# Altium schematic sheet Shunt.SchDoc
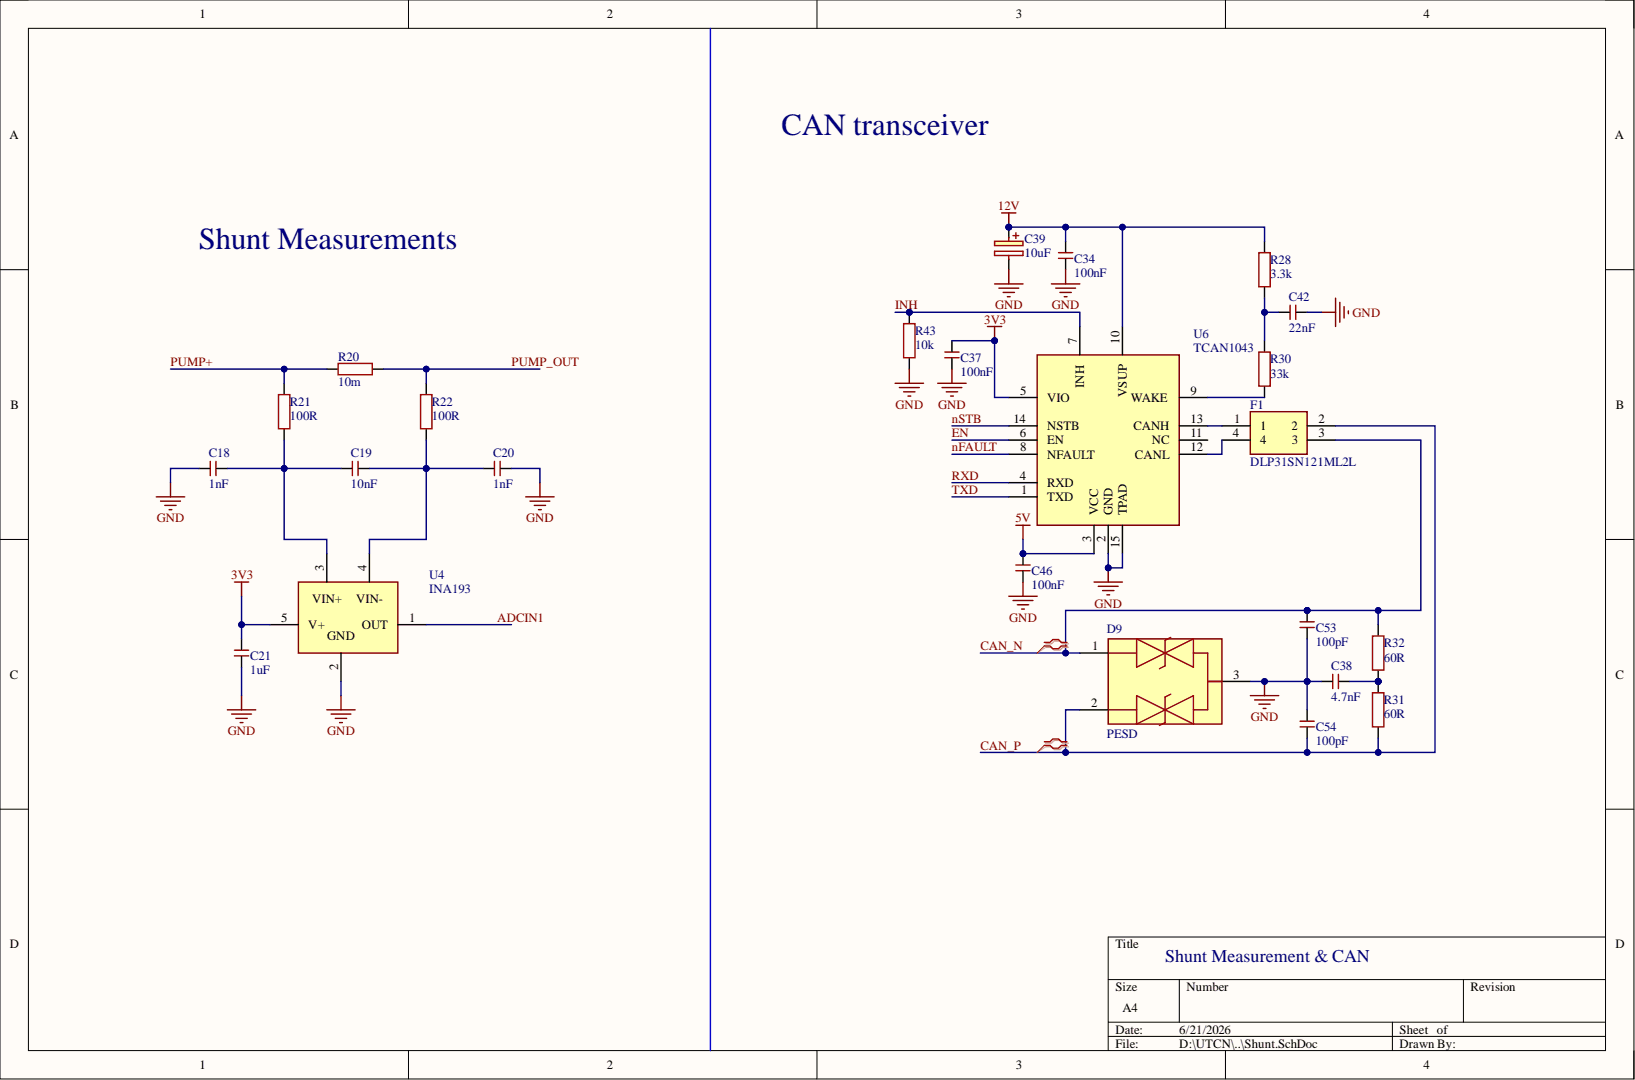
[diagram p0: Shunt.SchDoc]

## Parts
C18  1nF  [C0402]
C19  10nF  [C0402]
C20  1nF  [C0402]
C21  1uF  [C0402]
C34  100nF  [C0402]
C37  100nF  [C0402]
C38  4.7nF  [C0402]
C39  10uF  [CAPAE660X565N]
C42  22nF  [C0402]
C46  100nF  [C0402]
C53  100pF  [C0402]
C54  100pF  [C0402]
D9  PESD  [PESD2CANFD36LTQR]
F1  DLP31SN121ML2L  [DLP31SN121ML2B]
R20  10m  [CSS0402FT10L0]
R21  100R  [R0402]
R22  100R  [R0402]
R28  3.3k  [R0402]
R30  33k  [R0402]
R31  60R  [R0201]
R32  60R  [R0201]
R43  10k  [R0402]
U4  INA193  [SOT95P280X145-5N]
U6  TCAN1043  [SON65P300X450X90-15N]

## Nets
3V3: C21.2 C37.2 U4.5(V+) U6.5(VIO)
5V: C46.2 U6.3(VCC)
12V: C34.2 C39.1 R28.2 U6.10(VSUP)
GND: C18.1 C20.2 C21.1 C34.1 C37.1 C38.2 C39.2 C42.1 C46.1 C53.2 C54.1 D9.3 R43.1 U4.2 U6.2(GND) U6.15(TPAD)
ADCIN1: U4.1(OUT)
CAN_N: C53.1 D9.1 F1.3 R32.1
CAN_P: C54.2 D9.2 F1.2 R31.2
EN: U6.6(EN)
INH: R43.2 U6.7(INH)
nFAULT: U6.8(NFAULT)
nSTB: U6.14(NSTB)
PUMP+: R20.1 R21.2
PUMP_OUT: R20.2 R22.2
RXD: U6.4(RXD)
TXD: U6.1(TXD)
NetC18_2: C18.2 C19.1 R21.1 U4.3
NetC19_2: C19.2 C20.1 R22.1 U4.4
NetC38_1: C38.1 R31.1 R32.2
NetC42_2: C42.2 R28.1 R30.2
NetF1_1: F1.1 U6.13(CANH)
NetF1_4: F1.4 U6.12(CANL)
NetR30_1: R30.1 U6.9(WAKE)

Not connected: U6 11(NC)

## Library data of the parts
C18 C20 = C0402C102J5GACAUTO: Ceramic Capacitor, Multilayer, Ceramic, 50V, 5% +Tol, 5% -Tol, C0G, 30ppm/Cel TC, 0.001uF, Surface Mount, 0402; Manufacturer: KEMET; Multilayer: Yes; Reference Standard: AEC-Q200; Capacitor Type: Ceramic; Case/Package: 0402; Category: Ceramic Capacitors; Component Kind: Standard; ComponentLink1Description: https://datasheet.ciiva.com:443/pdfs/VipMasterIC/IC/KEME/KEME-S-A0008958445/KEME-S-A0008958445-1.pdf?src-supplier=IHS+Markit; ComponentLink1URL: https://datasheet.ciiva.com:443/pdfs/VipMasterIC/IC/KEME/KEME-S-A0008958445/KEME-S-A0008958445-1.pdf?src-supplier=IHS+Markit; ComponentLink2Description: http://www.farnell.com/datasheets/2750482.pdf?src-supplier=Farnell; ComponentLink2URL: http://www.farnell.com/datasheets/2750482.pdf?src-supplier=Farnell; ComponentLink3Description: https://datasheet.octopart.com/C0402C102J5GACAUTO-KEMET-datasheet-169773461.pdf?src-supplier=Newark; ComponentLink3URL: https://datasheet.octopart.com/C0402C102J5GACAUTO-KEMET-datasheet-169773461.pdf?src-supplier=Newark; ComponentLink4Description: http://www.farnell.com/datasheets/2750482.pdf?src-supplier=Newark; ComponentLink4URL: http://www.farnell.com/datasheets/2750482.pdf?src-supplier=Newark; ComponentLink5Description: https://api.kemet.com/component-edge/download/datasheet/C0402C102J5GACAUTO.pdf?src-supplier=Digikey; ComponentLink5URL: https://api.kemet.com/component-edge/download/datasheet/C0402C102J5GACAUTO.pdf?src-supplier=Digikey; ComponentLink6Description: http://www.kemet.com/docfinder?Partnumber=C0402C102J5GACAUTO&src-supplier=Digikey; ComponentLink6URL: http://www.kemet.com/docfinder?Partnumber=C0402C102J5GACAUTO&src-supplier=Digikey; ComponentLink7Description: https://datasheet.octopart.com/C0402C102J5GACAUTO-KEMET-datasheet-130259044.pdf?src-supplier=TME; ComponentLink7URL: https://datasheet.octopart.com/C0402C102J5GACAUTO-KEMET-datasheet-130259044.pdf?src-supplier=TME; Dielectric Material: Ceramic; Height: 0.5mm; JESD-609 Code: e3; Length: 1mm; Library Name: Schlib1.SchLib; Manufacturer Part Number: C0402C102J5GACAUTO; Max Operating Temperature: 125°C; Min Operating Temperature: -55°C; Mounting Technology: Surface Mount; Package Style: SMTMeter; Packaging: Tape and Reel; Pins: 2; Pricing: 1=0.12, 10=0.048, 50=0.048, 100=0.032, 1000=0.024, 10000=0.019 (USD); Rated DC Voltage (URdc): 50V; Reach SVHC Compliant: Yes; RoHS: Yes; RoHS Compliant: Yes; Stock: 231451; Supplier: Mouser; Supplier Part Number: 80-C0402C102J5GAUTO; Temperature Characteristics Code: C0G; Terminal Finish: Nickel; Tolerance  (fill in as +-): 5%; Tolerance (fill in as +-): 5%; Value: 1nF; Width: 0.5mm
C19 = C0402T103K4RALTU: Ceramic Capacitor, Multilayer, Ceramic, 16V, 10% +Tol, 10% -Tol, X7R, 15% TC, 0.01uF, Surface Mount, 0402; Manufacturer: KEMET; Multilayer: Yes; Capacitor Type: Ceramic; Case/Package: 0402; Category: Ceramic Capacitors; Component Kind: Standard; ComponentLink1Description: https://datasheet.ciiva.com:443/pdfs/VipMasterIC/IC/KEME/KEME-S-A0008487487/KEME-S-A0008487487-1.pdf?src-supplier=IHS+Markit; ComponentLink1URL: https://datasheet.ciiva.com:443/pdfs/VipMasterIC/IC/KEME/KEME-S-A0008487487/KEME-S-A0008487487-1.pdf?src-supplier=IHS+Markit; ComponentLink2Description: https://datasheet.ciiva.com/15379/kem-c1027-x7r-cots-smd-15379193.pdf?src-supplier=Mouser; ComponentLink2URL: https://datasheet.ciiva.com/15379/kem-c1027-x7r-cots-smd-15379193.pdf?src-supplier=Mouser; ComponentLink3Description: https://datasheet.ciiva.com/148/kem-c1027-x7r-cots-smd-148853.pdf?src-supplier=Digikey; ComponentLink3URL: https://datasheet.ciiva.com/148/kem-c1027-x7r-cots-smd-148853.pdf?src-supplier=Digikey; ComponentLink4Description: https://datasheet.ciiva.com/148/kem-c1027-x7r-cots-smd-148851.pdf?src-supplier=Digikey; ComponentLink4URL: https://datasheet.ciiva.com/148/kem-c1027-x7r-cots-smd-148851.pdf?src-supplier=Digikey; ComponentLink5Description: https://datasheet.ciiva.com/148/kem-c1027-x7r-cots-smd-148852.pdf?src-supplier=Digikey; ComponentLink5URL: https://datasheet.ciiva.com/148/kem-c1027-x7r-cots-smd-148852.pdf?src-supplier=Digikey; Dielectric Material: Ceramic; Height: 0.5mm; JESD-609 Code: e0; Length: 1mm; Library Name: Schlib1.SchLib; Manufacturer Part Number: C0402T103K4RALTU; Max Operating Temperature: 125°C; Min Operating Temperature: -55°C; Mounting Technology: Surface Mount; Package Shape: Rectangular; Package Style: SMTMeter; Packaging: Tape and Reel; Pins: 2; Pricing: 1=0.58, 10=0.42, 50=0.42, 100=0.337, 1000=0.294, 10000=0.246 (USD); Rated DC Voltage (URdc): 16V; Reach SVHC Compliant: No; RoHS: No; RoHS Compliant: No; Stock: 17779; Supplier: Mouser; Supplier Part Number: 80-C0402T103K4RALTU; Temperature Characteristics Code: X7R; Terminal Finish: Nickel; Termination: Wraparound; Tolerance  (fill in as +-): 10%; Tolerance (fill in as +-): 10%; Value: 10nF; Width: 0.5mm
C21 = C0402C105K4PAC7411: Ceramic Capacitor, Multilayer, Ceramic, 16V, 10% +Tol, 10% -Tol, X5R, 15% TC, 1uF, Surface Mount, 0402; Manufacturer: KEMET; Multilayer: Yes; Capacitor Type: Ceramic; Case/Package: 0402; Category: Ceramic Capacitors; Component Kind: Standard; ComponentLink1Description: https://datasheet.ciiva.com:443/pdfs/VipMasterIC/IC/KEME/KEME-S-A0008991665/KEME-S-A0008991665-1.pdf?src-supplier=IHS+Markit; ComponentLink1URL: https://datasheet.ciiva.com:443/pdfs/VipMasterIC/IC/KEME/KEME-S-A0008991665/KEME-S-A0008991665-1.pdf?src-supplier=IHS+Markit; ComponentLink2Description: https://api.kemet.com/component-edge/download/datasheet/C0402C105K4PAC7411.pdf?src-supplier=Digikey; ComponentLink2URL: https://api.kemet.com/component-edge/download/datasheet/C0402C105K4PAC7411.pdf?src-supplier=Digikey; ComponentLink3Description: https://datasheet.octopart.com/C0402C105K4PAC7411-KEMET-datasheet-142027389.pdf?src-supplier=Farnell; ComponentLink3URL: https://datasheet.octopart.com/C0402C105K4PAC7411-KEMET-datasheet-142027389.pdf?src-supplier=Farnell; Dielectric Material: Ceramic; Library Name: Schlib1.SchLib; Manufacturer Part Number: C0402C105K4PAC7411; Max Operating Temperature: 85°C; Min Operating Temperature: -55°C; Mounting Technology: Surface Mount; Package Shape: Rectangular; Packaging: Tape and Reel; Pins: 2; Pricing: 1=0.11, 10=0.061, 50=0.061, 100=0.037, 1000=0.024, 10000=0.019 (USD); Rated DC Voltage (URdc): 16V; Reach SVHC Compliant: Yes; RoHS: Yes; RoHS Compliant: Yes; Stock: 78488; Supplier: Mouser; Supplier Part Number: 80-C0402C105K4P7411; Temperature Characteristics Code: X5R; Termination: Wraparound; Tolerance  (fill in as +-): 10%; Tolerance (fill in as +-): 10%; Value: 1uF
C34 C37 C46 = C0402C104K3PACTU: Ceramic Capacitor, Multilayer, Ceramic, 25V, 10% +Tol, 10% -Tol, X5R, 15% TC, 0.1uF, Surface Mount, 0402; Manufacturer: KEMET; Multilayer: Yes; Capacitor Type: Ceramic; Case/Package: 0402; Category: Ceramic Capacitors; Component Kind: Standard; ComponentLink1Description: https://datasheet.ciiva.com:443/pdfs/VipMasterIC/IC/KEME/KEME-S-A0008991665/KEME-S-A0008991665-1.pdf?src-supplier=IHS+Markit; ComponentLink1URL: https://datasheet.ciiva.com:443/pdfs/VipMasterIC/IC/KEME/KEME-S-A0008991665/KEME-S-A0008991665-1.pdf?src-supplier=IHS+Markit; ComponentLink2Description: http://www.farnell.com/datasheets/2748284.pdf?src-supplier=Farnell; ComponentLink2URL: http://www.farnell.com/datasheets/2748284.pdf?src-supplier=Farnell; ComponentLink3Description: http://www.farnell.com/datasheets/2748284.pdf?src-supplier=Newark; ComponentLink3URL: http://www.farnell.com/datasheets/2748284.pdf?src-supplier=Newark; ComponentLink4Description: https://datasheet.octopart.com/C0402C104K3PACTU-KEMET-datasheet-181956028.pdf?src-supplier=Element14; ComponentLink4URL: https://datasheet.octopart.com/C0402C104K3PACTU-KEMET-datasheet-181956028.pdf?src-supplier=Element14; Dielectric Material: Ceramic; JESD-609 Code: e3; Library Name: Schlib1.SchLib; Manufacturer Part Number: C0402C104K3PACTU; Max Operating Temperature: 85°C; Min Operating Temperature: -55°C; Mounting Technology: Surface Mount; Package Shape: Rectangular; Packaging: Tape and Reel; Pins: 2; Pricing: 1=0.12, 10=0.056, 50=0.056, 100=0.036, 1000=0.026, 10000=0.017 (USD); Rated DC Voltage (URdc): 25V; Reach SVHC Compliant: Yes; RoHS: Yes; RoHS Compliant: Yes; Stock: 262570; Supplier: Mouser; Supplier Part Number: 80-C0402C104K3P; Temperature Characteristics Code: X5R; Terminal Finish: Nickel; Termination: Wraparound; Tolerance  (fill in as +-): 10%; Tolerance (fill in as +-): 10%; Value: 100nF
C38 = C0402C472J4JACAUTO: Cap Ceramic 0.0047uF 16V U2J 5% Pad SMD 0402 125C T/R; Manufacturer: KEMET; Category: Ceramic Capacitors; Component Kind: Standard; Library Name: Schlib1.SchLib; Manufacturer Part Number: C0402C472J4JACAUTO; Pricing: 1=0.48, 10=0.311, 50=0.311, 100=0.216, 1000=0.162, 10000=0.112 (USD); RoHS: No; Stock: 12001; Supplier: Mouser; Supplier Part Number: 80-C0402C472J4JAUTO
C39 = EXV106M050A9GAA: Aluminum Electrolytic Capacitor, Polarized, Aluminum (wet), 50V, 20% +Tol, 20% -Tol, 10uF, Surface Mount, 2626; Manufacturer: KEMET; Capacitor Type: Aluminium; ESR (Equivalent Series Resistance): 2.6R; Leakage Current: 5uA; Ripple Current: 98mA; Tan Delta: 0.12; Case/Package: 2626; Category: Aluminum Electrolytic Capacitors; Component Kind: Standard; ComponentLink1Description: https://datasheet.ciiva.com:443/pdfs/VipMasterIC/IC/KEME/KEME-S-A0009591402/KEME-S-A0009591402-1.pdf?src-supplier=IHS+Markit; ComponentLink1URL: https://datasheet.ciiva.com:443/pdfs/VipMasterIC/IC/KEME/KEME-S-A0009591402/KEME-S-A0009591402-1.pdf?src-supplier=IHS+Markit; ComponentLink2Description: https://datasheet.ciiva.com/22698/1500293-22698078.pdf?src-supplier=Element14; ComponentLink2URL: https://datasheet.ciiva.com/22698/1500293-22698078.pdf?src-supplier=Element14; Dielectric Material: Aluminium; Height: 5.4mm; Length: 7.8mm; Library Name: Schlib1.SchLib; Manufacturer Part Number: EXV106M050A9GAA; Max Operating Temperature: 105°C; Min Operating Temperature: -55°C; Mounting Technology: Surface Mount; Package Shape: Cylindrical; Package Style: SMTMeter; Packaging: Tape and Reel; Pins: 2; Polarity: Polarized; Pricing: 1=0.48, 10=0.255, 50=0.255, 100=0.165, 1000=0.139, 10000=0.112 (USD); Rated DC Voltage (URdc): 50V; Reach SVHC Compliant: Yes; RoHS: Yes; RoHS Compliant: Yes; Stock: 17264; Supplier: Mouser; Supplier Part Number: 80-EXV106M050A9GAA; Termination: Flat; Tolerance  (fill in as +-): 20%; Tolerance (fill in as +-): 20%; Value: 10uF; Width: 6.6mm
C42 = C0402C223J5RACTU: Ceramic Capacitor, Multilayer, Ceramic, 50V, 5% +Tol, 5% -Tol, X7R, 15% TC, 0.022uF, Surface Mount, 0402; Manufacturer: KEMET; Multilayer: Yes; Capacitor Type: Ceramic; Case/Package: 0402; Category: Ceramic Capacitors; Component Kind: Standard; ComponentLink1Description: https://datasheet.ciiva.com:443/pdfs/VipMasterIC/IC/KEME/KEME-S-A0008991654/KEME-S-A0008991654-1.pdf?src-supplier=IHS+Markit; ComponentLink1URL: https://datasheet.ciiva.com:443/pdfs/VipMasterIC/IC/KEME/KEME-S-A0008991654/KEME-S-A0008991654-1.pdf?src-supplier=IHS+Markit; ComponentLink2Description: http://capacitoredge.kemet.com/capedge2/DataSheet?pn=C0402C223J5RAC7867&src-supplier=Avnet; ComponentLink2URL: http://capacitoredge.kemet.com/capedge2/DataSheet?pn=C0402C223J5RAC7867&src-supplier=Avnet; Dielectric Material: Ceramic; Height: 0.5mm; JESD-609 Code: e3; Length: 1mm; Library Name: Schlib1.SchLib; Manufacturer Part Number: C0402C223J5RACTU; Max Operating Temperature: 125°C; Min Operating Temperature: -55°C; Mounting Technology: Surface Mount; Package Shape: Rectangular; Package Style: SMTMeter; Packaging: Tape and Reel; Pins: 2; Pricing: 1=0.3, 10=0.185, 50=0.185, 100=0.12, 1000=0.087, 10000=0.063 (USD); Rated DC Voltage (URdc): 50V; Reach SVHC Compliant: Yes; RoHS: Yes; RoHS Compliant: Yes; Stock: 18324; Supplier: Mouser; Supplier Part Number: 80-C0402C223J5RACTU; Temperature Characteristics Code: X7R; Terminal Finish: Nickel; Termination: Wraparound; Tolerance  (fill in as +-): 5%; Tolerance (fill in as +-): 5%; Value: 22nF; Width: 0.5mm
C53 C54 = C0402C101K3RACAUTO: Multilayer Ceramic Capacitors MLCC - SMD/SMT 25V 100pF X7R 0402 10% AEC-Q200; Manufacturer: KEMET; Category: Ceramic Capacitors; Component Kind: Standard; Library Name: Schlib1.SchLib; Manufacturer Part Number: C0402C101K3RACAUTO; Pricing: 1=0.1, 10=0.046, 50=0.046, 100=0.028, 1000=0.02, 10000=0.013 (USD); RoHS: No; Stock: 198813; Supplier: Mouser; Supplier Part Number: 80-C0402C101K3RAUTO
D9 = PESD2CANFD36LT-QR: ESD Protection Diodes / TVS Diodes ESD protection for in-vehicle networks; Manufacturer: Nexperia; Component Kind: Standard; Library Name: Schlib1.SchLib; Manufacturer Part Number: PESD2CANFD36LT-QR; Pricing: 1=0.31, 10=0.216, 50=0.216, 100=0.09, 1000=0.074, 10000=0.043 (USD); RoHS: No; Stock: 13324; Supplier: Mouser; Supplier Part Number: 771-PESD2CANFD36LTQR
F1 = DLP31SN121ML2L: Data Line Filter, 2 Function(s), 16V, 0.1A, 4 Pin(s); Manufacturer: Murata; Channels: 2; Current Rating: 100mA; DC Resistance-Max: 2R; Insulation Resistance-Min: 100MR; Output Impedance: 120R; Category: Common Mode Chokes; Component Kind: Standard; ComponentLink1Description: https://datasheet.ciiva.com:443/pdfs/VipMasterIC/IC/MURE/MURE-S-A0008512825/MURE-S-A0008513091-1.pdf?src-supplier=IHS+Markit; ComponentLink1URL: https://datasheet.ciiva.com:443/pdfs/VipMasterIC/IC/MURE/MURE-S-A0008512825/MURE-S-A0008513091-1.pdf?src-supplier=IHS+Markit; ComponentLink2Description: https://datasheet.ciiva.com/11799/0900766b80f15482-11799553.pdf?src-supplier=Rs; ComponentLink2URL: https://datasheet.ciiva.com/11799/0900766b80f15482-11799553.pdf?src-supplier=Rs; ComponentLink3Description: https://datasheet.ciiva.com/25666/l0114s0129dlp31s-25666023.pdf?src-supplier=Future+Electronics; ComponentLink3URL: https://datasheet.ciiva.com/25666/l0114s0129dlp31s-25666023.pdf?src-supplier=Future+Electronics; ComponentLink4Description: https://datasheet.ciiva.com/6214/l0114s0129dlp31s-6214636.pdf?src-supplier=Digikey; ComponentLink4URL: https://datasheet.ciiva.com/6214/l0114s0129dlp31s-6214636.pdf?src-supplier=Digikey; ComponentLink5Description: https://datasheet.ciiva.com/6214/l0114s0129dlp31s-6214639.pdf?src-supplier=Digikey; ComponentLink5URL: https://datasheet.ciiva.com/6214/l0114s0129dlp31s-6214639.pdf?src-supplier=Digikey; ComponentLink6Description: https://datasheet.ciiva.com/3068/l0114s0129dlp31s-3068654.pdf?src-supplier=Avnet; ComponentLink6URL: https://datasheet.ciiva.com/3068/l0114s0129dlp31s-3068654.pdf?src-supplier=Avnet; ComponentLink7Description: https://datasheet.ciiva.com/4942/muratadl-plseries-202927-4942133.pdf?src-supplier=Mouser; ComponentLink7URL: https://datasheet.ciiva.com/4942/muratadl-plseries-202927-4942133.pdf?src-supplier=Mouser; Filter Type: DATA LINE FILTER; Height: 1.15mm; JESD-609 Code: e4; Length: 3.2mm; Library Name: Schlib1.SchLib; Manufacturer Part Number: DLP31SN121ML2L; Max Operating Temperature: 85°C; Min Operating Temperature: -40°C; Mounting Technology: Surface Mount; Packaging: Tape and Reel; Pricing: 1=1.54, 10=1.27, 50=1.07, 100=1.05, 1000=0.985, 10000=0.771 (USD); Reach SVHC Compliant: Yes; RoHS: Yes; RoHS Compliant: Yes; Stock: 3150; Supplier: Mouser; Supplier Part Number: 81-DLP31SN121ML2L; Terminal Finish: Silver; Voltage Rating: 16V; Width: 1.6mm
R20 = CSS0402FT10L0: Fixed Resistor, Metal Alloy, 0.33W, 0.01ohm, 1% +/-Tol, 100ppm/Cel, Surface Mount, 0402; Manufacturer: Stackpole Electronics; Category: Chip SMD Resistors; Component Kind: Standard; Library Name: Schlib1.SchLib; Manufacturer Part Number: CSS0402FT10L0; Pricing: 1=0.44, 10=0.272, 50=0.225, 100=0.187, 1000=0.142, 10000=0.116 (USD); RoHS: Yes; Stock: 3752; Supplier: Mouser; Supplier Part Number: 708-CSS0402FT10L0
R21 R22 = RP0402DRD07100RL: Res, 100R, 0.5%, 0.063W, Thin Film, 0402 Rohs Compliant: Yes; Manufacturer: Yageo; Category: Chip SMD Resistors; Component Kind: Standard; Library Name: Schlib1.SchLib; Manufacturer Part Number: RP0402DRD07100RL; Pricing: 1=0.21, 10=0.156, 50=0.122, 100=0.11, 1000=0.073, 10000=0.049 (USD); RoHS: No; Stock: 9231; Supplier: Mouser; Supplier Part Number: 603-RP0402DRD07100RL
R28 = RC0402FR-7D3K3L: Fixed Resistor, Metal Glaze/thick Film, 0.0625W, 3300ohm, 50V, 1% +/-Tol, 100ppm/Cel, Surface Mount, 0402; Manufacturer: Yageo; Additional Feature: LASER TRIMMABLE; Package Height: 0.35mm; Package Length: 1mm; Package Width: 0.5mm; Power: 62.5mW; Rated Temperature: 70°C; Temperature Coefficient (ppm/Cel): 100; Value: 3.3kR; Case/Package: 0402; Category: Resistors; Component Kind: Standard; Construction: Chip; JESD-609 Code: e3; Library Name: Schlib1.SchLib; Manufacturer Part Number: RC0402FR-7D3K3L; Max Operating Temperature: 155°C; Min Operating Temperature: -55°C; Mounting Technology: Surface Mount; Package Shape: Rectangular; Packaging: Tape and Reel; Pins: 2; Pricing: 1=0.1, 10=0.01, 50=0.01, 100=0.007, 1000=0.006, 10000=0.003 (USD); Reach SVHC Compliant: Yes; Resistor Type: FIXED RESISTOR; RoHS: Yes; RoHS Compliant: Yes; Stock: 400223; Supplier: Mouser; Supplier Part Number: 603-RC0402FR-7D3K3L; Technology: METAL GLAZE/THICK FILM; Terminal Finish: Nickel; Termination: Wraparound; Tolerance: 1%; Working Voltage: 50V
R30 = RC0402FR-7D33KL: Fixed Resistor, Metal Glaze/thick Film, 0.0625W, 33000ohm, 50V, 1% +/-Tol, 100ppm/Cel, Surface Mount, 0402; Manufacturer: Yageo; Category: Resistors; Component Kind: Standard; Library Name: Schlib1.SchLib; Manufacturer Part Number: RC0402FR-7D33KL; Pricing: 1=0.1, 10=0.01, 50=0.01, 100=0.007, 1000=0.006, 10000=0.003 (USD); RoHS: Yes; Stock: 38172; Supplier: Mouser; Supplier Part Number: 603-RC0402FR-7D33KL
R31 R32 = RK73H1HRTTCM60R4F: Fixed Resistor, Metal Glaze/thick Film, 0.05W, 60.4ohm, 25V, 1% +/-Tol, 300ppm/Cel, Surface Mount, 0201; Manufacturer: KOA Speer; Category: LDO; Component Kind: Standard; Library Name: Schlib1.SchLib; Manufacturer Part Number: RK73H1HRTTCM60R4F; Pricing: 1=0.16, 10=0.079, 50=0.079, 100=0.046, 1000=0.028, 10000=0.02 (USD); Reach SVHC Compliant: Yes; RoHS: Yes; RoHS Compliant: Yes; Stock: 38859; Supplier: Mouser; Supplier Part Number: 660-RK73H1HRTTCM60R4
R43 = RP0402BRD0710KL: Resistor, 10K, 0.1%, 0.063W, THIN FILM, 0402;; Manufacturer: Yageo; Category: Chip SMD Resistors; Component Kind: Standard; ComponentLink1Description: https://datasheet.octopart.com/RP0402BRD0710KL-Yageo-datasheet-179178423.pdf?src-supplier=Newark; ComponentLink1URL: https://datasheet.octopart.com/RP0402BRD0710KL-Yageo-datasheet-179178423.pdf?src-supplier=Newark; Library Name: Schlib1.SchLib; Manufacturer Part Number: RP0402BRD0710KL; Pricing: 1=0.24, 10=0.171, 50=0.134, 100=0.12, 1000=0.092, 10000=0.074 (USD); RoHS: No; Stock: 21771; Supplier: Mouser; Supplier Part Number: 603-RP0402BRD0710KL
U4 = INA193AQDBVRQ1: Operational Amplifier, 1 Func, PDSO5; Manufacturer: Texas Instruments; Adjustable Threshold: No; Channels: 1; Max Supply Voltage: 18V; Min Supply Voltage: 2.7V; Nominal Supply Voltage: 12V; Package Body Material: Plastic; Package Equivalence Code: TSOP5/6,.11,37; Qualification Status: Not Qualified; Supply Current-Max (Isup): 1.25mA; Throw Configuration: POWER SUPPLY SUPPORT CIRCUIT; Case/Package: LSSOP; Category: Amplifiers - Op Amps, Buffer, Instrumentation; Component Kind: Standard; ComponentLink1Description: https://www.ti.com:443/lit/ds/symlink/ina193a-q1.pdf?src-supplier=IHS+Markit; ComponentLink1URL: https://www.ti.com:443/lit/ds/symlink/ina193a-q1.pdf?src-supplier=IHS+Markit; ComponentLink2Description: https://datasheet.ciiva.com/1939/ina193a-q1-1939597.pdf?src-supplier=Digikey; ComponentLink2URL: https://datasheet.ciiva.com/1939/ina193a-q1-1939597.pdf?src-supplier=Digikey; ComponentLink3Description: https://datasheet.ciiva.com/1577/1383809-1577443.pdf?src-supplier=Newark; ComponentLink3URL: https://datasheet.ciiva.com/1577/1383809-1577443.pdf?src-supplier=Newark; ComponentLink4Description: https://datasheet.ciiva.com/4875/sbos366c-126160-4875330.pdf?src-supplier=Mouser; ComponentLink4URL: https://datasheet.ciiva.com/4875/sbos366c-126160-4875330.pdf?src-supplier=Mouser; ComponentLink5Description: https://datasheet.ciiva.com/15134/getdatasheetpartid-255678-15134586.pdf?src-supplier=Verical; ComponentLink5URL: https://datasheet.ciiva.com/15134/getdatasheetpartid-255678-15134586.pdf?src-supplier=Verical; ComponentLink6Description: https://datasheet.ciiva.com/2977/ina198a-q1-2977933.pdf?src-supplier=Avnet; ComponentLink6URL: https://datasheet.ciiva.com/2977/ina198a-q1-2977933.pdf?src-supplier=Avnet; ComponentLink7Description: https://datasheet.ciiva.com/2977/ina198a-q1-2977934.pdf?src-supplier=Avnet; ComponentLink7URL: https://datasheet.ciiva.com/2977/ina198a-q1-2977934.pdf?src-supplier=Avnet; Height - Seated (Max): 1.45mm; JESD-30 Code: R-PDSO-G5; JESD-609 Code: e4; Length: 2.9mm; Library Name: Schlib1.SchLib; Manufacturer Part Number: INA193AQDBVRQ1; Max Operating Temperature: 125°C; Min Operating Temperature: -40°C; Moisture Sensitivity Level: 2; Number of Channels: 1; Package Shape: Rectangular; Package Style: SMALL OUTLINE, LOW PROFILE, SHRINK PITCHMeter; Peak Reflow Temperature (Cel): 260°C; Pins: 5; Power Supplies: 12V; Pricing: 1=4.07, 10=3.08, 50=2.83, 100=2.56, 1000=2.29, 10000=2.18 (USD); Reach SVHC Compliant: Yes; RoHS: Yes; RoHS Compliant: Yes; Stock: 19974; Supplier: Mouser; Supplier Part Number: 595-INA193AQDBVRQ1; Surface Mount: Yes; Temperature Grade: AUTOMOTIVE; Terminal Finish: Nickel; Terminal Form: Gull Wing; Terminal Pitch: 0.95mm; Terminal Position: DUAL; Width: 1.6mm
U6 = TCAN1043DMTRQ1: Ethernet Transceiver, 1-Trnsvr, PDSO14; Manufacturer: Texas Instruments; Category: Interface ICs; Component Kind: Standard; ComponentLink1Description: https://www.ti.com:443/lit/ds/symlink/tcan1043-q1.pdf?src-supplier=IHS+Markit; ComponentLink1URL: https://www.ti.com:443/lit/ds/symlink/tcan1043-q1.pdf?src-supplier=IHS+Markit; ComponentLink2Description: http://www.ti.com/lit/ds/symlink/tcan1043-q1.pdf?src-supplier=Digikey; ComponentLink2URL: http://www.ti.com/lit/ds/symlink/tcan1043-q1.pdf?src-supplier=Digikey; JESD-609 Code: e3; Library Name: Schlib1.SchLib; Manufacturer Part Number: TCAN1043DMTRQ1; Moisture Sensitivity Level: 2; Pricing: 1=2.47, 10=1.84, 50=1.68, 100=1.51, 1000=1.33, 10000=1.16 (USD); Reach SVHC Compliant: Yes; RoHS: Yes; RoHS Compliant: Yes; Stock: 28707; Supplier: Mouser; Supplier Part Number: 595-TCAN1043DMTRQ1; Telecom IC Type: ETHERNET TRANSCEIVER; Terminal Finish: Tin
